# Altium schematic sheet Power.SchDoc
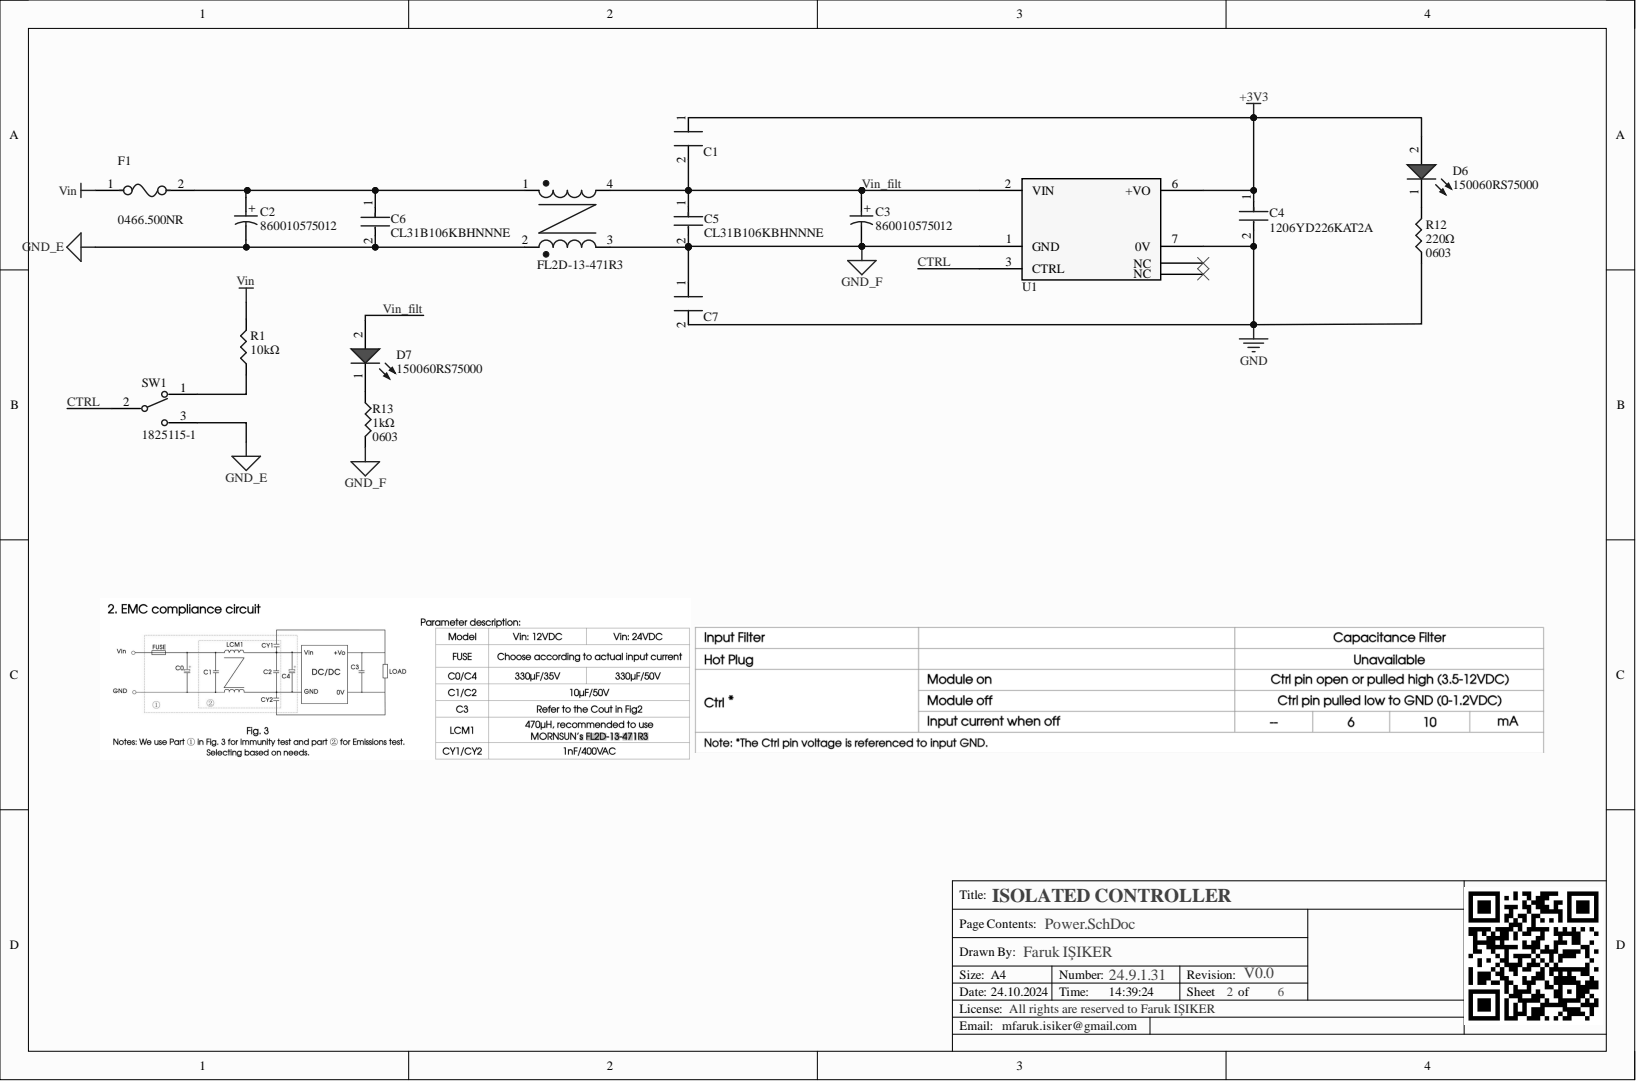
[diagram p0: Power.SchDoc]

## Parts
C1  1nf 400V AC 7.5mm Lead  [PCBComponent_1]  (value not drawn)
C2  860010575012  [WCAP-ATG8_D10H12.5]
C3  860010575012  [WCAP-ATG8_D10H12.5]
C4  1206YD226KAT2A  [FP-1206-L_3_2_0_2-W_1_6_0-IPC_A]
C5  CL31B106KBHNNNE  [FP-CL31B106KBHNNNE-IPC_A]
C6  CL31B106KBHNNNE  [FP-CL31B106KBHNNNE-IPC_A]
C7  1nf 400V AC 7.5mm Lead  [PCBComponent_1]  (value not drawn)
D6  150060RS75000  [WL-SMCW_0603_150060xx75000]
D7  150060RS75000  [WL-SMCW_0603_150060xx75000]
F1  0466.500NR  [FP-0466-MFG]
FL2D-13-471R3  EMC Filte  [FL2D-13-471R3]  (value not drawn)
R1  value 10kΩ  [0603-smd]  (hidden comment: 0603_10K)
R12  0603_220R  value 220Ω  Package: 0603  [0603-smd]
R13  value 1kΩ  Package: 0603  [0603-smd]  (hidden comment: 0603_1K)
SW1  1825115-1  [FP-1825115-1-MFG]
U1  DC DC CONVERTER 3.3V 6W  [VRB_S-6WR3_MNS]  (value not drawn)

## Nets
+3V3: C1.1 C4.1 D6.2 U1.6(+VO)
GND: C4.2 C7.2 R12.1 U1.7(0V)
GND_E: C2.2 C6.2 FL2D-13-471R3.2 SW1.3
GND_F: C3.2 C5.2 C7.1 FL2D-13-471R3.3 R13.2 U1.1(GND)
Vin: F1.1 R1.2
CTRL: SW1.2 U1.3(CTRL)
Vin_filt: C1.2 C3.1 C5.1 D7.2 FL2D-13-471R3.4 U1.2(VIN)
NetC2_1: C2.1 C6.1 F1.2 FL2D-13-471R3.1
NetD6_1: D6.1 R12.2
NetD7_1: D7.1 R13.1
NetR1_1: R1.1 SW1.1
NetU1_5: U1.5(NC)
NetU1_8: U1.8(NC)

## Library data of the parts
C1 C7 = C717U102MYVDBA7317: Suppression Cap, 1000Pf, Class X1/ Y2 Rohs Compliant: Yes |Kemet C717U102MYVDBA7317; Manufacturer: KEMET; Category: Ceramic Capacitors; Component Kind: Standard; ComponentLink1Description: Datasheet; ComponentLink1URL: https://content.kemet.com/datasheets/KEM_C1103_KJY.pdf; Library Name: Schlib1.SchLib; Manufacturer Part Number: C717U102MYVDBA7317; Pricing 1: 1=0,72, 10=0,387, 50=0,387, 100=0,25, 1000=0,223, 10000=0,18 (USD); RoHS: Yes; RoHS Compliant: Yes; Stock 1: 1838; Supplier 1: Mouser; Supplier Part Number 1: 80-C717U102MYVDB7317
C2 C3 = CMP-03913-54007241-1: 860010575012 WCAP-ATG8 Aluminum Electrolytic Capacitors; Capacitance: 330µF; Leakage Current: 115.5µA; Life (Hours): 2000hours; Pitch: 5mm; Ripple Current: 528mA; Tolerance: 20%; Voltage Rating: 35V; Case/Package: Radial; Component Kind: Standard; Diameter: 10mm; Height: 12.5mm; Lead Pitch: 5mm; Length: 12.5mm; Library Name: Altium Content Vault; Max Operating Temperature: 85°C; Min Operating Temperature: -40°C; NexarPartId: 54007241; REACH SVHC: Unknown; RoHS: Non-Compliant; Voltage Rating (DC): 35V
C4 = CMP-1037-04992-2: General Purpose Ceramic Capacitor, 1206, 22uF, 10%, X5R, 15%, 16V; Capacitance: 22uF; Case Code (Metric): 3216; Case Code (Imperial): 1206; Case/Package: 1206; Component Kind: Standard; Construction: Flat; Contact Plating: Tin; Depth: 1.6mm; Dielectric: X5R; Height: 0.07mm; Lead Free: Lead Free; Length: 3.2mm; Library Name: Altium Content Vault; Max Operating Temperature: 85°C; Min Operating Temperature: -55°C; Mount: Surface Mount; Number of Pins: 2; Package Quantity: 1; Packaging: Tape and Reel; Radiation Hardening: No; REACH SVHC: No SVHC; RoHS Compliant: Yes; Series: -; Termination: SMD/SMT; Thickness: 0.07inch; Tolerance: 10%; Voltage Rating: 16V; Voltage Rating (DC): 16V; Width: 0.063inch
C5 C6 = CMP-2000-07530-2: CAP CER 10UF 50V X7R 1206; Manufacturer: Samsung; Material: Ceramic; Temperature Coefficient: 15%; Tolerance: 10%; Automotive: No; Capacitance: 10uF; Category: Cap; Component Kind: Standard; ComponentLink2Description: Datasheet; ComponentLink2URL: http://www.samsungsem.com/global/front/downloadcms.do?path=%2F%2Fglobal%2F%2Fsupport%2F%2Fproduct-search%2F%2Fmlcc%2F%2F__icsFiles%2F%2Fafieldfile%2F%2F2019%2F%2F06%2F%2F18&fileName=CL31B106KBHNNNE-19.pdf; Device Class L1: Passive Components; Device Class L2: Capacitors; Device Class L3: Ceramic Capacitors; Digikey Description: CAP CER 10UF 50V X7R 1206; Height: 1.8mm; Lead Free: Yes; Library Name: Altium Content Vault; Manufacturer Part Number: CL31B106KBHNNNE; Octopart: https://octopart.com/cl31b106kbhnnne-samsung-30507377; Package: CL31B106KBHNNNE; RoHS: TRUE; Temperature: -55°C to +125°C; Temperature Characteristic: X7R; Temperature Range High: +125°C; Temperature Range Low: -55°C; Value: 10uF; Voltage Rating: 50V
D6 D7 = CMP-1426-00001-3: NexarPartId: 29717776; Color: Red; Dominant Wavelength: 625nm; Forward Current: 100mA; Forward Voltage: 2V; Lens Style: Clear, Rectangular; Luminous Intensity: 250mcd; Max Junction Temperature (Tj): 95°C; Max Reverse Voltage (DC): 5V; Peak Wavelength: 630nm; Power Dissipation: 72mW; Test Current: 20mA; Viewing Angle: 140°; Case Code (Metric): 1608; Case Code (Imperial): 0603; Case/Package: 0603; Component Kind: Standard; Height: 700µm; Length: 1.6mm; Library Name: Altium Content Vault; Max Operating Temperature: 85°C; Min Operating Temperature: -40°C; Mount: Surface Mount; Packaging: Tape and Reel; REACH SVHC: Unknown; Reverse Voltage: 5V; RoHS: Compliant; Wavelength: 625nm; Width: 800µm
F1 = CMP-08605-000018-1: FUSE BOARD MOUNT 500MA 63VAC/VDC; Manufacturer: Littelfuse Inc; Fuse Blow Speed: Fast; Fuse Current: 0.5A; Fuse Voltage Drop: 158.47mV; Fuse I2T: 0.006A2Sec; Fuse Interrupt Ac Current: 50A; Max Fuse Ac Voltage: 63V; Resistance: 0.248Ω; Value: 0.248Ω; Automotive: No; Category: Fuse; Component Kind: Standard; ComponentLink2Description: Datasheet; ComponentLink2URL: https://www.littelfuse.com/~/media/electronics/datasheets/fuses/littelfuse_fuse_466_datasheet.pdf.pdf; Device Class L1: Circuit Protection; Device Class L2: Fuses; Device Class L3: unset; Digikey Description: FUSE BOARD MOUNT 500MA 63VAC/VDC; Fuse Interrupt Dc Current: 50A; Height: 0.66mm; Lead Free: Yes; Library Name: Altium Content Vault; Manufacturer Part Number: 0466.500NR; Max Fuse Dc Voltage: 63V; Mouser Description: SMD Fuse 0.5 A Fast-Blow, 466 1206; Octopart: https://octopart.com/0466.500nr-littelfuse-566004; Package: 0466; RoHS: TRUE; Temperature: -55°C to +90°C; Temperature Range High: +90°C; Temperature Range Low: -55°C; Voltage Rating: 63V
FL2D-13-471R3 = FL2D-13-471R3: Component Kind: Standard; ComponentLink1Description: Datasheet; ComponentLink1URL: https://www.mornsun-power.com/html/pdf/FL2D-13-471R3.html; Library Name: FL2D-13-471R3.SchLib
R1 = 0603_10K: Power: W; Component Kind: Standard; Library Name: generic.SchLib; Package: 0603
R12 = 0603_220R: Power: W; Component Kind: Standard; Library Name: generic.SchLib
R13 = 0603_1K: Power: W; Component Kind: Standard; Library Name: generic.SchLib
SW1 = CMP-03405-000003-1: SWITCH SLIDE SPDT 250MA 125V; Manufacturer: TE Connectivity; Contact Resistance: 30mΩ; Electromechanical Life: 6000Cycles; Operating Force: 250gf; Voltage Rating Dc: 12V; Automotive: No; Category: Switch; Component Kind: Standard; ComponentLink2Description: Datasheet; ComponentLink2URL: https://www.te.com/usa-en/product-1825115-1.html?te_bu=Cor&te_type=other&te_campaign=oct_glo_cor-oct-global-oth-prtnr-fy19-oct-octo-buy-bom_sma-298_2&elqCampaignId=35216; Contact Current Rating: 250mA; Device Class L1: Electromechanical; Device Class L2: Switches; Device Class L3: Slide Switches; Digikey Description: SWITCH SLIDE SPDT 250MA 125V; Lead Free: Yes; Library Name: Altium Content Vault; Manufacturer Part Number: 1825115-1; Mount: Through Hole; Octopart: https://octopart.com/sls121pc04-te+connectivity-39426454; Package: 1825115-1; RoHS: TRUE; Temperature: -10°C to +85°C; Temperature Range High: +85°C; Temperature Range Low: -10°C; Throw Configuration: SPDT; Voltage Rating Ac: 125V
U1 = VRB1203S-6WR3: Isolated Module DC DC Converter 1 Output 3.3V 1.35A 9V - 18V Input; Mfr_Name: MORNSUN; Component Kind: Standard; ComponentLink1Description: Datasheet; ComponentLink1URL: https://www.mornsun-power.com/html/pdf/VRB1203S-6WR3.html; Library Name: VRB1203S-6WR3.SchLib; RefDes: RefDes; Type: DC DC Converters
